# Altium schematic sheet 01 POWER.SchDoc
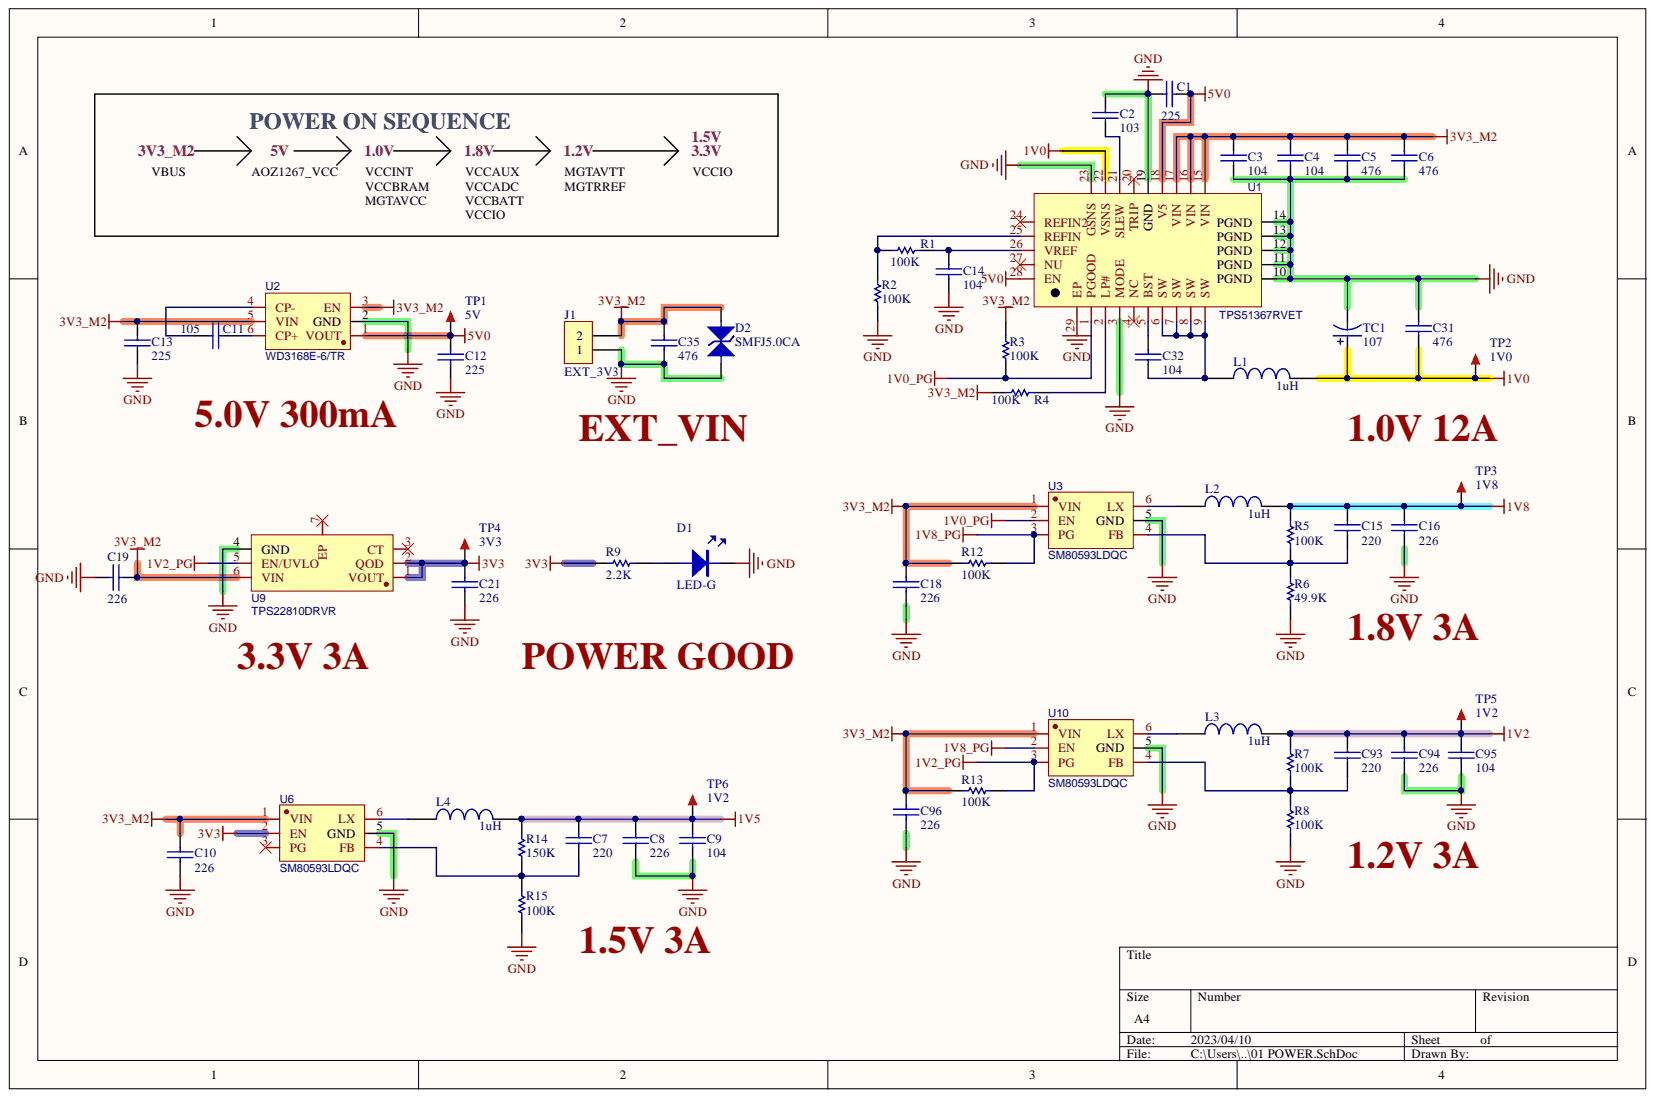
[diagram p0: 01 POWER.SchDoc]

## Parts
C1  225  [0402C]
C2  103  [0402C]
C3  104  [0402C]
C4  104  [0402C]
C5  476  [0603C]
C6  476  [0603C]
C7  220  [0402C]
C8  226  [0603C]
C9  104  [0402C]
C10  226  [0603C]
C11  105  [0402C]
C12  225  [0402C]
C13  225  [0402C]
C14  104  [0402C]
C15  220  [0402C]
C16  226  [0603C]
C18  226  [0603C]
C19  226  [0603C]
C21  226  [0603C]
C31  476  [0603C]
C32  104  [0402C]
C35  476  [0603C]
C93  220  [0402C]
C94  226  [0603C]
C95  104  [0402C]
C96  226  [0603C]
D1  LED-G  [LED-0603G]
D2  SMFJ5.0CA  [SOD-123]
J1  EXT_3V3  [Pin HDR1X2/2.54mm-W]
L1  1uH  [0618L]
L2  1uH  [252012L]
L3  1uH  [252012L]
L4  1uH  [252012L]
R1  100K  [0402R]
R2  100K  [0402R]
R3  100K  [0402R]
R4  100K  [0402R]
R5  100K  [0402R]
R6  49.9K  [0402R]
R7  100K  [0402R]
R8  100K  [0402R]
R9  2.2K  [0402R]
R12  100K  [0402R]
R13  100K  [0402R]
R14  150K  [0402R]
R15  100K  [0402R]
TC1  107  [TAJ-A/3216]
TP1  5V  [TEST 0.8]
TP2  1V0  [TEST 0.8]
TP3  1V8  [TEST 0.8]
TP4  3V3  [TEST 0.8]
TP5  1V2  [TEST 0.8]
TP6  1V2  [TEST 0.8]
U1  TPS51367RVET  [VQFN-28_L4.5-W3.5-P0.40-BL-EP]
U2  WD3168E-6/TR  [SOT23-6]
U3  SM80593LDQC  [DFN-6_L1.5-W1.5-P0.50-BL]
U6  SM80593LDQC  [DFN-6_L1.5-W1.5-P0.50-BL]
U9  TPS22810DRVR  [WSON-6_L2.0-W2.0-P0.65-TL-EP]
U10  SM80593LDQC  [DFN-6_L1.5-W1.5-P0.50-BL]

## Nets
1V0: C31.1 L1.2 TC1.1 TP2.1 U1.22(VSNS)
1V0_PG: R3.1 U1.1(PGOOD) U3.2(EN)
1V2: C93.1 C94.1 C95.1 L3.2 R7.1 TP5.1
1V2_PG: R13.2 U9.5(EN/UVLO) U10.3(PG)
1V5: C7.1 C8.1 C9.1 L4.2 R14.1 TP6.1
1V8: C15.1 C16.1 L2.2 R5.1 TP3.1
1V8_PG: R12.2 U3.3(PG) U10.2(EN)
3V3: C21.1 R9.1 TP4.1 U6.2(EN) U9.1(VOUT) U9.2(QOD)
3V3_M2: C3.2 C4.2 C5.2 C6.2 C10.1 C13.1 C18.1 C19.1 C35.2 C96.1 D2.A J1.2 R3.2 R4.2 R12.1 R13.1 U1.15(VIN) U1.16(VIN) U1.17(VIN) U2.3(EN) U2.5(VIN) U3.1(VIN) U6.1(VIN) U9.6(VIN) U10.1(VIN)
5V0: C1.2 C12.1 TP1.1 U1.18(V5) U1.28(EN) U2.1(VOUT)
GND: C1.1 C2.1 C3.1 C4.1 C5.1 C6.1 C8.2 C9.2 C10.2 C12.2 C13.2 C14.1 C16.2 C18.2 C19.2 C21.2 C31.2 C35.1 C94.2 C95.2 C96.2 D1.K D2.K J1.1 R2.2 R6.2 R8.2 R15.2 TC1.2 U1.3(MODE) U1.10(PGND) U1.11(PGND) U1.12(PGND) U1.13(PGND) U1.14(PGND) U1.19(GND) U1.23(GSNS) U1.29(EP) U2.2(GND) U3.5(GND) U6.5(GND) U9.4(GND) U10.5(GND)
NetC2_2: C2.2 U1.21(SLEW)
NetC7_2: C7.2 R14.2 R15.1 U6.4(FB)
NetC11_1: C11.1 U2.4(CP-)
NetC11_2: C11.2 U2.6(CP+)
NetC14_2: C14.2 R1.1 U1.26(VREF)
NetC15_2: C15.2 R5.2 R6.1 U3.4(FB)
NetC32_1: C32.1 L1.1 U1.6(SW) U1.7(SW) U1.8(SW) U1.9(SW)
NetC32_2: C32.2 U1.5(BST)
NetC93_2: C93.2 R7.2 R8.1 U10.4(FB)
NetD1_A: D1.A R9.2
NetL2_1: L2.1 U3.6(LX)
NetL3_1: L3.1 U10.6(LX)
NetL4_1: L4.1 U6.6(LX)
NetR1_2: R1.2 R2.1 U1.25(REFIN)
NetR4_1: R4.1 U1.2(LP#)

Not connected: U1 4(NC) 20(TRIP) 24(REFIN2) 27(NU); U6 3(PG); U9 3(CT) 7(EP)

## Library data of the parts
C1-C16 C18 C19 C21 C31 C32 C35 C93-C96 = CAP: 无极性电容; Component Kind: Standard; Library Name: 电容.IntLib
D1 = LED: Component Kind: Standard; Library Name: LED.IntLib
D2 = TVS: 双向瞬态抑制二极管; Component Kind: Standard; Library Name: 二极管.IntLib
J1 = Pin HDR1X2: Component Kind: Standard; Library Name: 排针.IntLib
L1-L4 = INDUCTOR: Component Kind: Standard; Description: INDUCTOR; Library Name: 电感.IntLib
R1-R9 R12-R15 = RES: Component Kind: Standard; Library Name: 电阻.IntLib
TC1 = TCAP: 钽电容; Component Kind: Standard; Library Name: 电容.IntLib
TP1-TP6 = TEST: 测试点; 版权归属: 源创客; BOM名称: 测试点; Component Kind: Standard; 学习资源: www.ooocke.com; Library Name: 测试调试.IntLib
U1 = TPS51367RVET: BOM_Manufacturer: TI(德州仪器); Contributor: LCSC; BOM_JLCPCB Part Class: Extended Part; BOM_Manufacturer Part: TPS51367RVET; BOM_Supplier: LCSC; BOM_Supplier Part: C201948; Component Kind: Standard; Library Name: 未分类IC.IntLib; spicePre: U; spiceSymbolName: TPS51367RVET
U2 = WD3168E-6/TR: BOM_Manufacturer: WILLSEMI(韦尔); Contributor: LCSC; BOM_JLCPCB Part Class: Extended Part; BOM_Manufacturer Part: WD3168E-6/TR; BOM_Supplier: LCSC; BOM_Supplier Part: C239652; Component Kind: Standard; Library Name: 未分类IC.IntLib; spicePre: U; spiceSymbolName: WD3168E-6/TR
U3 U6 U10 = SM80593LDQC: BOM_Manufacturer: silergy(矽力杰); Contributor: lcsc; BOM_Manufacturer Part: SM80593LDQC; BOM_Supplier: LCSC; BOM_Supplier Part: C3151903; Component Kind: Standard; Library Name: 未分类IC.IntLib; link: https://atta.szlcsc.com/upload/public/pdf/source/20220628/A6CA9B87DD4EAA9C1464F36D4D99E4DA.pdf; spicePre: U; spiceSymbolName: SM80593LDQC
U9 = TPS22810DRVR: BOM_Manufacturer: TI(德州仪器); Contributor: LCSC; BOM_JLCPCB Part Class: Extended Part; BOM_Manufacturer Part: TPS22810DRVR; BOM_Supplier: LCSC; BOM_Supplier Part: C527679; Component Kind: Standard; Library Name: 未分类IC.IntLib; spicePre: U; spiceSymbolName: TPS22810DRVR
